# Altium schematic sheet AD_Transverter.SchDoc(AD_Transverter)
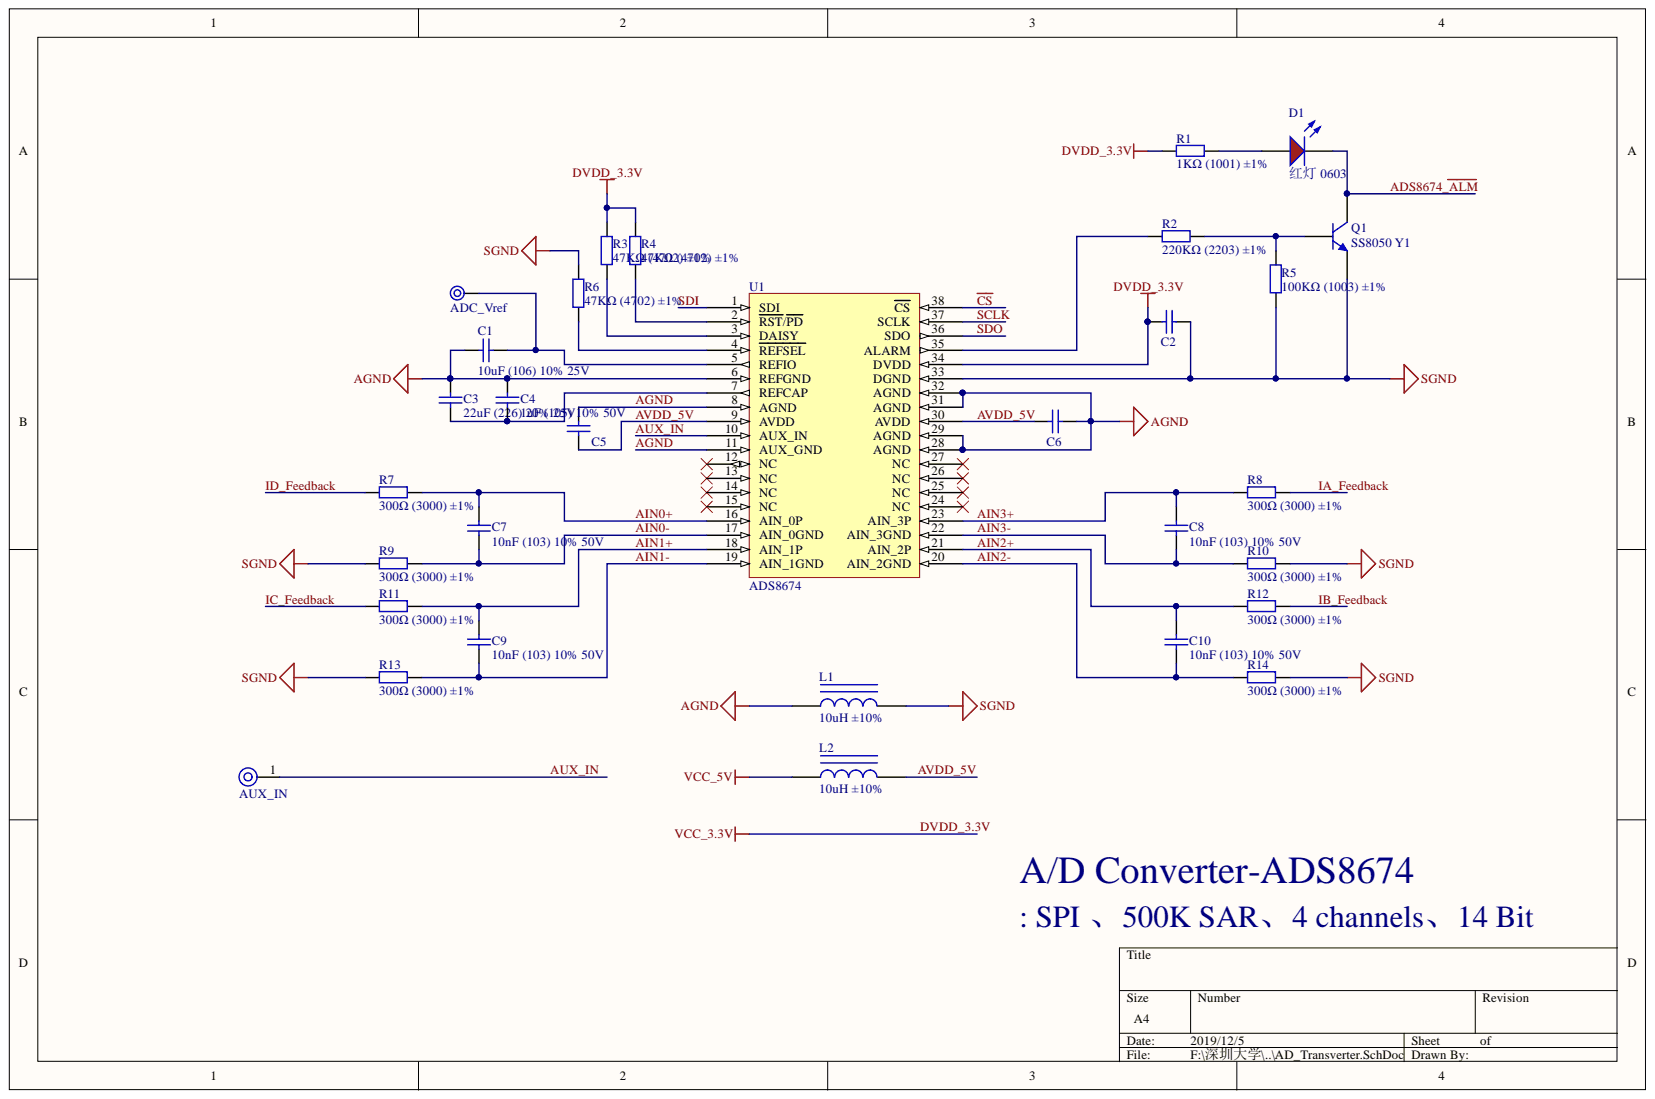
[diagram p0: AD_Transverter.SchDoc]

## Parts
ADC_Vref  TP_Surface  [TEST_POINT_ME]  (value not drawn)
AUX_IN  TestPoint  [TestPoint]  (value not drawn)
C1  10uF (106) 10% 25V  [0805_C]
C2  10uF (106) 10% 10V  [0603_C]  (value not drawn)
C3  22uF (226) 20% 25V  [0805_C]
C4  1uF (105) 10% 50V  [0603_C]  (value not drawn)
C5  1uF (105) 10% 50V  [0603_C]
C6  1uF (105) 10% 50V  [0603_C]  (value not drawn)
C7  10nF (103) 10% 50V  [0603_C]
C8  10nF (103) 10% 50V  [0603_C]
C9  10nF (103) 10% 50V  [0603_C]
C10  10nF (103) 10% 50V  [0603_C]
D1  红灯 0603  Package: 0603  [0603_LED_S1]
L1  10uH ±10%  [0805_L]
L2  10uH ±10%  [0805_L]
Q1  SS8050 Y1  [SOT-23(SOT-23-3)]
R1  1KΩ (1001) ±1%  [0603_R]
R2  220KΩ (2203) ±1%  [0603_R]
R3  47KΩ (4702) ±1%  [0603_R]
R4  47KΩ (4702) ±1%  [0603_R]
R5  100KΩ (1003) ±1%  [0603_R]
R6  47KΩ (4702) ±1%  [0603_R]
R7  300Ω (3000) ±1%  [0603_R]
R8  300Ω (3000) ±1%  [0603_R]
R9  300Ω (3000) ±1%  [0603_R]
R10  300Ω (3000) ±1%  [0603_R]
R11  300Ω (3000) ±1%  [0603_R]
R12  300Ω (3000) ±1%  [0603_R]
R13  300Ω (3000) ±1%  [0603_R]
R14  300Ω (3000) ±1%  [0603_R]
U1  ADS8674  [ADS8674_TSSOP50P640X120-38N]

## Nets
SGND: C2.2 L1.2 Q1.2 R5.1 R6.2 R9.1 R10.1 R13.1 R14.1 U1.33(DGND)
VCC_5V: L2.1
ADS8674_~{ALM}: D1.K Q1.3
AGND: C1.1 C3.2 C4.2 C5.1 C6.2 L1.1 U1.6(REFGND) U1.8(AGND) U1.11(AUX_GND) U1.28(AGND) U1.29(AGND) U1.31(AGND) U1.32(AGND)
AIN0+: C7.2 R7.2 U1.16(AIN_0P)
AIN0-: C7.1 R9.2 U1.17(AIN_0GND)
AIN1+: C9.2 R11.2 U1.18(AIN_1P)
AIN1-: C9.1 R13.2 U1.19(AIN_1GND)
AIN2+: C10.1 R12.2 U1.21(AIN_2P)
AIN2-: C10.2 R14.2 U1.20(AIN_2GND)
AIN3+: C8.1 R8.2 U1.23(AIN_3P)
AIN3-: C8.2 R10.2 U1.22(AIN_3GND)
AUX_IN: AUX_IN.1 U1.10(AUX_IN)
AVDD_5V: C5.2 C6.1 L2.2 U1.9(AVDD) U1.30(AVDD)
~{CS}: U1.38(CS)
DVDD_3.3V: C2.1 R1.1 R3.2 R4.2 U1.34(DVDD)
IA_Feedback: R8.1
IB_Feedback: R12.1
IC_Feedback: R11.1
ID_Feedback: R7.1
SCLK: U1.37(SCLK)
SDI: U1.1(SDI)
SDO: U1.36(SDO)
NetADC_Vref_1: ADC_Vref.1 C1.2 U1.5(REFIO)
NetC3_1: C3.1 C4.1 U1.7(REFCAP)
NetD1_A: D1.A R1.2
NetQ1_1: Q1.1 R2.2 R5.2
NetR2_1: R2.1 U1.35(ALARM)
NetR3_1: R3.1 U1.3(DAISY)
NetR4_1: R4.1 U1.2(RST/PD)
NetR6_1: R6.1 U1.4(REFSEL)

Not connected: U1 12(NC) 13(NC) 14(NC) 15(NC) 24(NC) 25(NC) 26(NC) 27(NC)

## Library data of the parts
AUX_IN = TestPoint: 信号测试点; Component Kind: Standard; Library Name: MPLM_Driver_V10A1.IntLib
C1 = 0805 10uF (106) 10% 25V: 贴片电容; SuppliersPartNumber: C15850; Supplier: LC; Package: 0805; Library Name: JLC Library.IntLib; ComponentLink1URL: http://www.szlcsc.com/product/details_16532.html; ComponentLink1Description: 供应商链接; Component Kind: Standard
C2 = 0603 10uF (106) 10% 10V: 贴片电容; SuppliersPartNumber: C19702; Supplier: LC; Package: 0603; Library Name: JLC Library.IntLib; ComponentLink1URL: http://www.szlcsc.com/product/details_20411.html; ComponentLink1Description: 供应商链接; Component Kind: Standard
C3 = 0805 22uF (226) 20% 25V: 贴片电容; SuppliersPartNumber: C45783; Supplier: LC; Package: 0805; Library Name: JLC Library.IntLib; ComponentLink1URL: http://www.szlcsc.com/product/details_46786.html; ComponentLink1Description: 供应商链接; Component Kind: Standard
C4-C6 = 0603 1uF (105) 10% 50V: 贴片电容; SuppliersPartNumber: C15849; Supplier: LC; Package: 0603; Library Name: JLC Library.IntLib; ComponentLink1URL: http://www.szlcsc.com/product/details_16531.html; ComponentLink1Description: 供应商链接; Component Kind: Standard
C7-C10 = 0603 10nF (103) 10% 50V: 贴片电容; SuppliersPartNumber: C57112; Supplier: LC; Package: 0603; Library Name: JLC Library.IntLib; ComponentLink1URL: http://www.szlcsc.com/product/details_58143.html; ComponentLink1Description: 供应商链接; Component Kind: Standard
D1 = 0603 红灯: 发光二极管; SuppliersPartNumber: C2286; Supplier: LC; Library Name: JLC Library.IntLib; ComponentLink1URL: http://www.szlcsc.com/product/details_2659.html; ComponentLink1Description: 供应商链接; Component Kind: Standard
L1 L2 = 0805 10uH 10%: 贴片电感; SuppliersPartNumber: C1046; Supplier: LC; Package: 0805; Library Name: JLC Library.IntLib; ComponentLink1URL: http://www.szlcsc.com/product/details_1398.html; ComponentLink1Description: 供应商链接; Component Kind: Standard
Q1 = SOT-23 SS8050 Y1: 三极管; SuppliersPartNumber: C2150; Supplier: LC; Package: SOT-23; Library Name: JLC Library.IntLib; ComponentLink1URL: http://www.szlcsc.com/product/details_2507.html; ComponentLink1Description: 供应商链接; Component Kind: Standard
R1 = 0603 1KΩ (1001) 1%: 贴片电阻; SuppliersPartNumber: C21190; Supplier: LC; Package: 0603; Library Name: JLC Library.IntLib; ComponentLink1URL: http://www.szlcsc.com/product/details_21904.html; ComponentLink1Description: 供应商链接; Component Kind: Standard
R2 = 0603 220KΩ (2203) 1%: 贴片电阻; SuppliersPartNumber: C22961; Supplier: LC; Package: 0603; Library Name: JLC Library.IntLib; ComponentLink1URL: http://www.szlcsc.com/product/details_23688.html; ComponentLink1Description: 供应商链接; Component Kind: Standard
R3 R4 R6 = 0603 47KΩ (4702) 1%: 贴片电阻; SuppliersPartNumber: C25819; Supplier: LC; Package: 0603; Library Name: JLC Library.IntLib; ComponentLink1URL: http://www.szlcsc.com/product/details_26562.html; ComponentLink1Description: 供应商链接; Component Kind: Standard
R5 = 0603 100KΩ (1003) 1%: 贴片电阻; SuppliersPartNumber: C25803; Supplier: LC; Package: 0603; Library Name: JLC Library.IntLib; ComponentLink1URL: http://www.szlcsc.com/product/details_26546.html; ComponentLink1Description: 供应商链接; Component Kind: Standard
R7-R14 = 0603 300Ω (3000) 1%: 贴片电阻; SuppliersPartNumber: C23025; Supplier: LC; Package: 0603; Library Name: JLC Library.IntLib; ComponentLink1URL: http://www.szlcsc.com/product/details_23752.html; ComponentLink1Description: 供应商链接; Component Kind: Standard
ADC_Vref = TP_Surface: Component Kind: Standard; Library Name: PSRM_Sensorless_V2.0模块.IntLib
U1 = ADS8674: Component Kind: Standard; Library Name: BG7TSM自画库.IntLib
